# Altium schematic sheet nRF-MCU.SchDoc
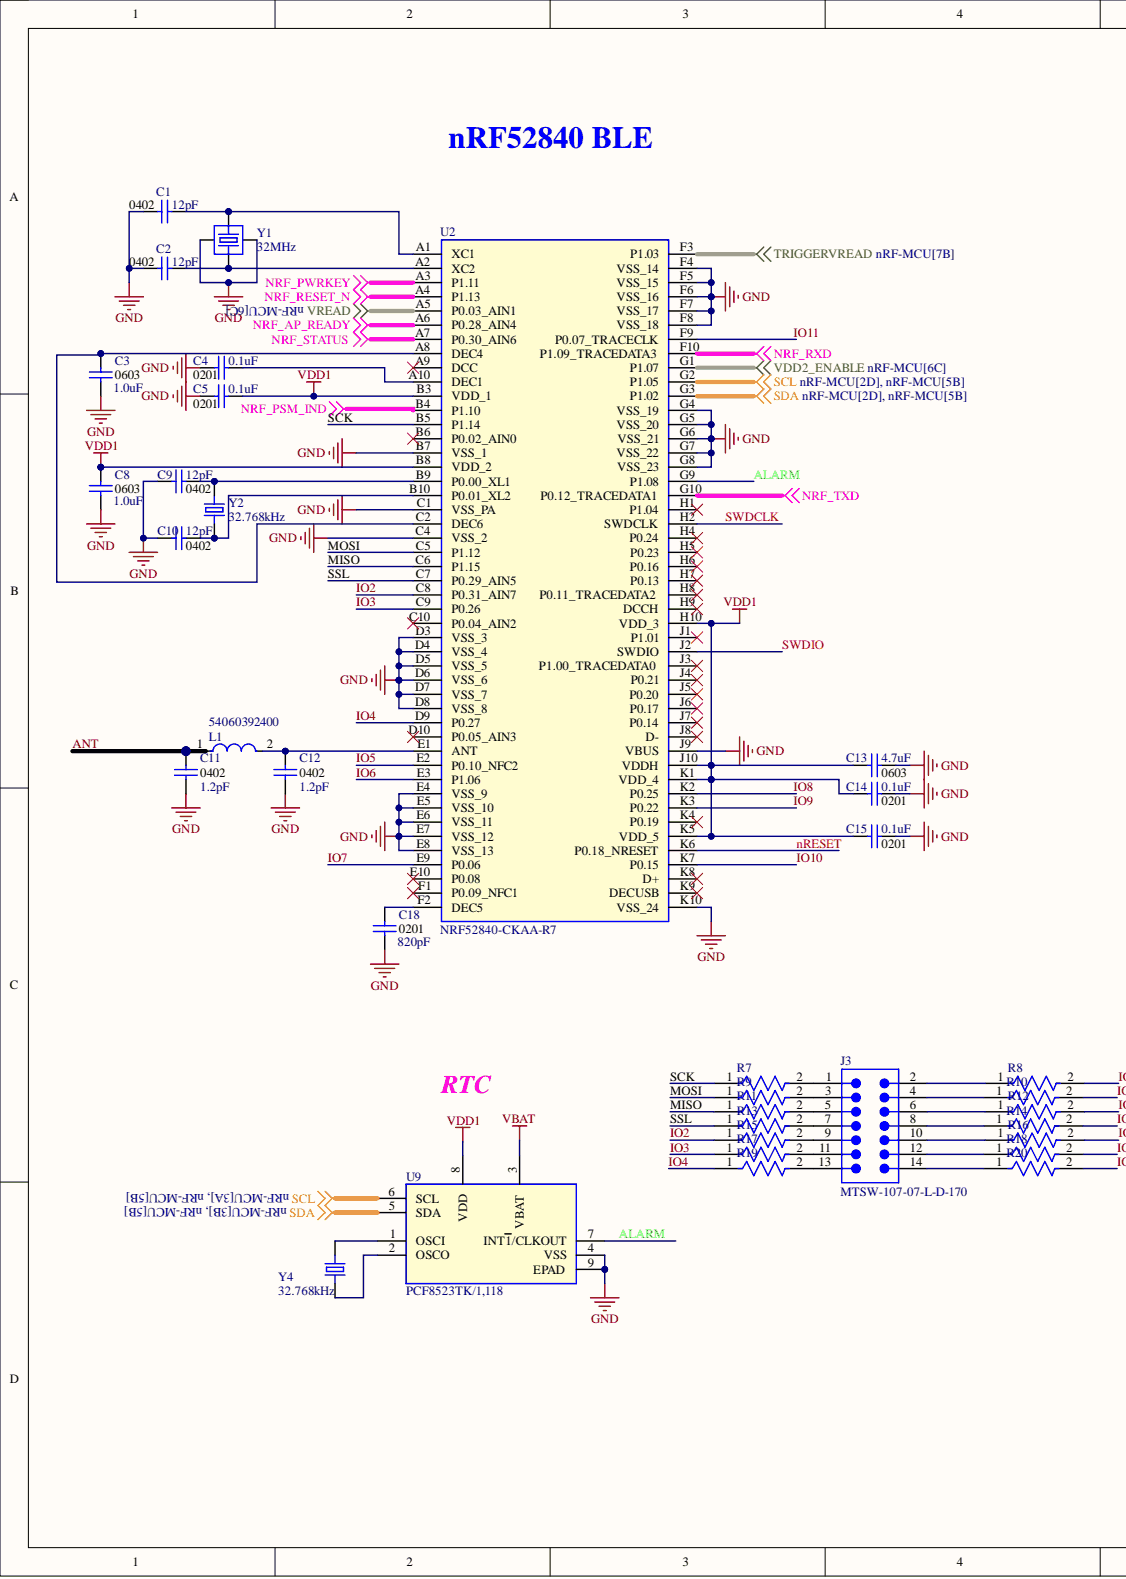
[diagram p0: nRF-MCU.SchDoc, left]
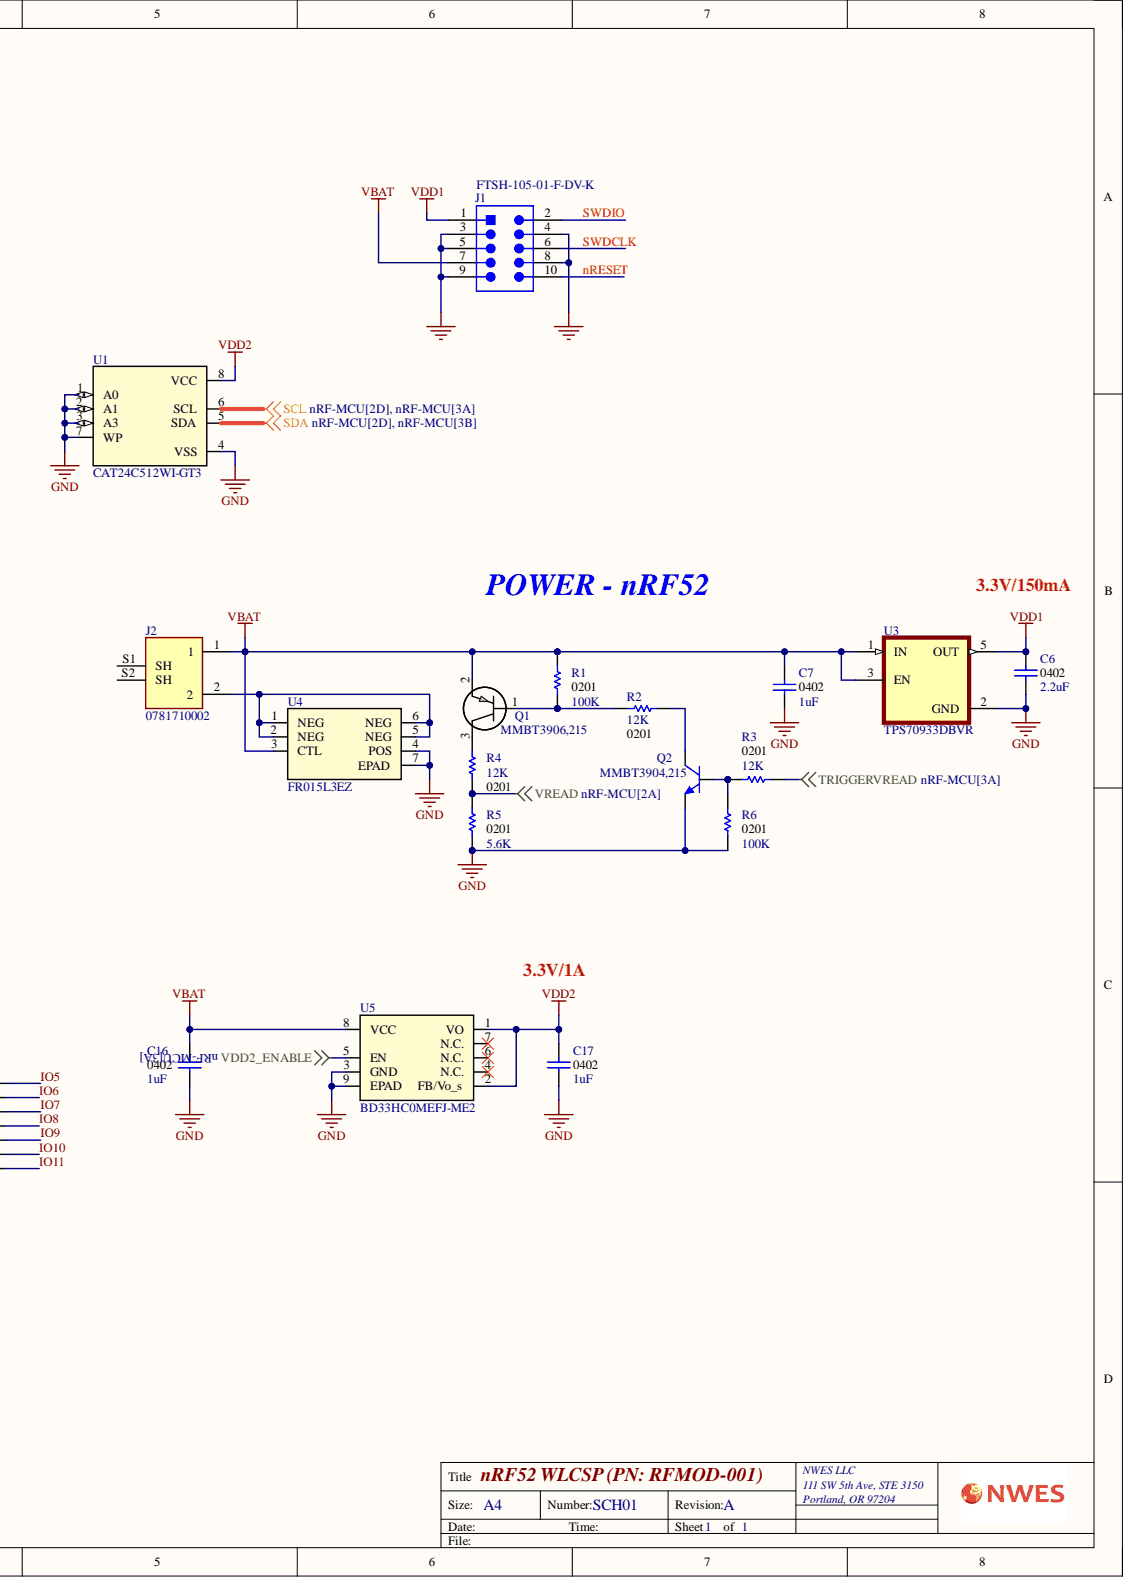
[diagram p1: nRF-MCU.SchDoc, right]

## Parts
C1  12pF  Package: 0402  [CAPC1005X55USR]
C2  12pF  Package: 0402  [CAPC1005X55USR]
C3  1.0uF  Package: 0603  [CAPC1608]
C4  0.1uF  Package: 0201  [CAPC0603X33N]
C5  0.1uF  Package: 0201  [CAPC0603X33N]
C6  2.2uF  Package: 0402  [CAPC1005X55USR]
C7  1uF  Package: 0402  [CAPC1005X55USR]
C8  1.0uF  Package: 0603  [CAPC1608]
C9  12pF  Package: 0402  [CAPC1005X55USR]
C10  12pF  Package: 0402  [CAPC1005X55USR]
C11  1.2pF  Package: 0402  [CAPC1005X55USR]
C12  1.2pF  Package: 0402  [CAPC1005X55USR]
C13  4.7uF  Package: 0603  [CAPC1608]
C14  0.1uF  Package: 0201  [CAPC0603X33N]
C15  0.1uF  Package: 0201  [CAPC0603X33N]
C16  1uF  Package: 0402  [CAPC1005X55USR]
C17  1uF  Package: 0402  [CAPC1005X55USR]
C18  820pF  Package: 0201  [CAPC0603X33N]
J1  FTSH-105-01-F-DV-K  [SMTC-FTSH-105-01-L-DV-K_V]
J2  0781710002  [MOLEX_0781710002]
J3  MTSW-107-07-L-D-170  [SMTC-MTSW-107-07-X-D-170]
L1  54060392400  [FP-LQW18AN_00-MFG]
Q1  MMBT3906,215  [SOT-23]
Q2  MMBT3904,215  [SOT-23]
R1  100K  Package: 0201  [RESC0603X26N]
R2  12K  Package: 0201  [RESC0603X26N]
R3  12K  Package: 0201  [RESC0603X26N]
R4  12K  Package: 0201  [RESC0603X26N]
R5  5.6K  Package: 0201  [RESC0603X26N]
R6  100K  Package: 0201  [RESC0603X26N]
R7  CRCW040222R0JNED  [FP-CRCW0402-e3-MFG]  (value not drawn)
R8  CRCW040222R0JNED  [FP-CRCW0402-e3-MFG]  (value not drawn)
R9  CRCW040222R0JNED  [FP-CRCW0402-e3-MFG]  (value not drawn)
R10  CRCW040222R0JNED  [FP-CRCW0402-e3-MFG]  (value not drawn)
R11  CRCW040222R0JNED  [FP-CRCW0402-e3-MFG]  (value not drawn)
R12  CRCW040222R0JNED  [FP-CRCW0402-e3-MFG]  (value not drawn)
R13  CRCW040222R0JNED  [FP-CRCW0402-e3-MFG]  (value not drawn)
R14  CRCW040222R0JNED  [FP-CRCW0402-e3-MFG]  (value not drawn)
R15  CRCW040222R0JNED  [FP-CRCW0402-e3-MFG]  (value not drawn)
R16  CRCW040222R0JNED  [FP-CRCW0402-e3-MFG]  (value not drawn)
R17  CRCW040222R0JNED  [FP-CRCW0402-e3-MFG]  (value not drawn)
R18  CRCW040222R0JNED  [FP-CRCW0402-e3-MFG]  (value not drawn)
R19  CRCW040222R0JNED  [FP-CRCW0402-e3-MFG]  (value not drawn)
R20  CRCW040222R0JNED  [FP-CRCW0402-e3-MFG]  (value not drawn)
U1  CAT24C512WI-GT3  [SOIC-8]
U2  NRF52840-CKAA-R7  [BGA94C35P10X10_354X361X51]
U3  TPS70933DBVR  [SOT23-5]
U4  FR015L3EZ  [MLP6_2X2_ONS]
U5  BD33HC0MEFJ-ME2  [HTSOP-J8_ROM]
U9  PCF8523TK/1,118  [HVSON80P400X400X100-9N]
Y1  32MHz  [XTAL_2016_ECS_320]
Y2  32.768kHz  [XTAL_3215]
Y4  32.768kHz  [XTAL_3215]

## Nets
GND: C1.2 C2.2 C3.2 C4.2 C5.2 C6.1 C7.1 C8.2 C9.2 C10.2 C11.1 C12.1 C13.1 C14.1 C15.1 C16.1 C17.1 C18.2 J1.3 J1.4 J1.5 J1.8 J1.9 Q2.2 R5.1 R6.1 U1.1(A0) U1.2(A1) U1.3(A3) U1.4(VSS) U1.7(WP) U2.B7(VSS_1) U2.C1(VSS_PA) U2.C4(VSS_2) U2.D3(VSS_3) U2.D4(VSS_4) U2.D5(VSS_5) U2.D6(VSS_6) U2.D7(VSS_7) U2.D8(VSS_8) U2.E4(VSS_9) U2.E5(VSS_10) U2.E6(VSS_11) U2.E7(VSS_12) U2.E8(VSS_13) U2.F4(VSS_14) U2.F5(VSS_15) U2.F6(VSS_16) U2.F7(VSS_17) U2.F8(VSS_18) U2.G4(VSS_19) U2.G5(VSS_20) U2.G6(VSS_21) U2.G7(VSS_22) U2.G8(VSS_23) U2.J9(VBUS) U2.K10(VSS_24) U3.2(GND) U4.4(POS) U4.7(EPAD) U5.3(GND) U5.9(EPAD) U9.4(VSS) U9.9(EPAD) Y1.2 Y1.4
NRF_AP_READY: U2.A6(P0.28_AIN4)
NRF_PSM_IND: U2.B4(P1.10)
NRF_PWRKEY: U2.A3(P1.11)
NRF_RESET_N: U2.A4(P1.13)
NRF_RXD: U2.F10(P1.09_TRACEDATA3)
NRF_STATUS: U2.A7(P0.30_AIN6)
NRF_TXD: U2.G10(P0.12_TRACEDATA1)
SCL: U1.6(SCL) U2.G2(P1.05) U9.6(SCL)
SDA: U1.5(SDA) U2.G3(P1.02) U9.5(SDA)
TRIGGERVREAD: R3.1 U2.F3(P1.03)
VBAT: C7.2 C16.2 J1.7 J2.1 Q1.2 R1.2 U3.1(IN) U3.3(EN) U4.3(CTL) U5.8(VCC) U9.3(VBAT)
VDD1: C5.1 C6.2 C8.1 C13.2 C14.2 C15.2 J1.1 U2.B3(VDD_1) U2.B8(VDD_2) U2.H10(VDD_3) U2.J10(VDDH) U2.K1(VDD_4) U2.K5(VDD_5) U3.5(OUT) U9.8(VDD)
VDD2: C17.2 U1.8(VCC) U5.1(VO) U5.2(FB/Vo_s)
VDD2_ENABLE: U2.G1(P1.07) U5.5(EN)
VREAD: R4.2 R5.2 U2.A5(P0.03_AIN1)
ALARM: U2.G9(P1.08) U9.7(INT1/CLKOUT)
ANT: C11.2 L1.1
IO2: R15.1 U2.C8(P0.31_AIN7)
IO3: R17.1 U2.C9(P0.26)
IO4: R19.1 U2.D9(P0.27)
IO5: R8.2 U2.E2(P0.10_NFC2)
IO6: R10.2 U2.E3(P1.06)
IO7: R12.2 U2.E9(P0.06)
IO8: R14.2 U2.K2(P0.25)
IO9: R16.2 U2.K3(P0.22)
IO10: R18.2 U2.K7(P0.15)
IO11: R20.2 U2.F9(P0.07_TRACECLK)
MISO: R11.1 U2.C6(P1.15)
MOSI: R9.1 U2.C5(P1.12)
nRESET: J1.10 U2.K6(P0.18_NRESET)
SCK: R7.1 U2.B5(P1.14)
SSL: R13.1 U2.C7(P0.29_AIN5)
SWDCLK: J1.6 U2.H2(SWDCLK)
SWDIO: J1.2 U2.J2(SWDIO)
NetC1_1: C1.1 U2.A1(XC1) Y1.1
NetC2_1: C2.1 U2.A2(XC2) Y1.3
NetC3_1: C3.1 U2.A8(DEC4) U2.C2(DEC6)
NetC4_1: C4.1 U2.A10(DEC1)
NetC9_1: C9.1 U2.B9(P0.00_XL1) Y2.2
NetC10_1: C10.1 U2.B10(P0.01_XL2) Y2.1
NetC12_2: C12.2 L1.2 U2.E1(ANT)
NetC18_1: C18.1 U2.F2(DEC5)
NetJ2_2: J2.2 U4.1(NEG) U4.2(NEG) U4.5(NEG) U4.6(NEG)
NetJ3_1: J3.1 R7.2
NetJ3_2: J3.2 R8.1
NetJ3_3: J3.3 R9.2
NetJ3_4: J3.4 R10.1
NetJ3_5: J3.5 R11.2
NetJ3_6: J3.6 R12.1
NetJ3_7: J3.7 R13.2
NetJ3_8: J3.8 R14.1
NetJ3_9: J3.9 R15.2
NetJ3_10: J3.10 R16.1
NetJ3_11: J3.11 R17.2
NetJ3_12: J3.12 R18.1
NetJ3_13: J3.13 R19.2
NetJ3_14: J3.14 R20.1
NetQ1_1: Q1.1 R1.1 R2.2
NetQ1_3: Q1.3 R4.1
NetQ2_1: Q2.1 R3.2 R6.2
NetQ2_3: Q2.3 R2.1
NetU9_1: U9.1(OSCI) Y4.2
NetU9_2: U9.2(OSCO) Y4.1

Not connected: J2 S1(SH) S2(SH); U2 A9(DCC) B6(P0.02_AIN0) C10(P0.04_AIN2) D10(P0.05_AIN3) E10(P0.08) F1(P0.09_NFC1) H1(P1.04) H4(P0.24) H5(P0.23) H6(P0.16) H7(P0.13) H8(P0.11_TRACEDATA2) H9(DCCH) J1(P1.01) J3(P1.00_TRACEDATA0) J4(P0.21) J5(P0.20) J6(P0.17) J7(P0.14) J8(D-) K4(P0.19) K8(D+) K9(DECUSB); U5 4(N.C.) 6(N.C.) 7(N.C.)

## Library data of the parts
C1 C2 C9 C10 = CAP CER 12PF 50V C0G/NP0 0402: Manufacturer: YAGEO; Manufacturer Part Number: CC0402GRNPO9BN120; Component Kind: Standard; Description: CAP CER 12PF 50V C0G/NP0 0402; Height: 0.55mm; Supplier 1: Digi-Key; Supplier Part Number 1: 311-3609-1-ND; value: 12pF; Library Name: nRF52-Intro.SCHLIB
C3 C8 = CAP CER 1UF 16V X7R 0603: Manufacturer: KEMET; Manufacturer Part Number: C0603C105K4RAC7867; Component Kind: Standard; Description: CAP CER 1UF 16V X7R 0603; Height: 0.9mm; Supplier 1: Digi-Key; Supplier Part Number 1: 399-C0603C105K4RAC7867CT-ND; value: 1.0uF; Library Name: nRF52-Intro.SCHLIB
C4 C5 C14 C15 = CAP CER 0.1UF 6.3V X7R 0201: Manufacturer: YAGEO; Manufacturer Part Number: CC0201KRX7R5BB104; Component Kind: Standard; Description: CAP CER 0.1UF 6.3V X7R 0201; Height: 0.33mm; Supplier 1: Digi-Key; Supplier Part Number 1: 13-CC0201KRX7R5BB104CT-ND; value: 0.1uF; Library Name: nRF52-Intro.SCHLIB
C6 = CAP CER 2.2UF 25V X5R 0402: Manufacturer: Murata Electronics; Manufacturer Part Number: GRM155R61E225ME15D; Component Kind: Standard; Description: CAP CER 2.2UF 25V X5R 0402; Height: 0.55mm; Supplier 1: Digi-Key; Supplier Part Number 1: 490-10457-1-ND; value: 2.2uF; Library Name: nRF52-Intro.SCHLIB
C7 C16 C17 = CAP CER 1UF 25V X5R 0402: Manufacturer: Murata Electronics; Manufacturer Part Number: GRM155C81E105ME11J; Component Kind: Standard; Description: CAP CER 1UF 25V X5R 0402; Height: 0.6mm; Supplier 1: Digi-Key; Supplier Part Number 1: 490-GRM155C81E105ME11JCT-ND; value: 1uF; Library Name: nRF52-Intro.SCHLIB
C11 C12 = CAP CER 1.2PF 50V C0G/NP0 0402: Manufacturer: Murata Electronics; Manufacturer Part Number: GCM1555C1H1R2BA16D; Component Kind: Standard; Description: CAP CER 1.2PF 50V C0G/NP0 0402; Height: 0.55mm; Supplier 1: Digi-Key; Supplier Part Number 1: 490-16453-1-ND; value: 1.2pF; Library Name: nRF52-Intro.SCHLIB
C13 = CAP CER 4.7UF 6.3V X7R 0603: Manufacturer: Taiyo Yuden; Manufacturer Part Number: JMK107BB7475KA-T; Component Kind: Standard; Description: CAP CER 4.7UF 6.3V X7R 0603; Height: 1.0mm; Supplier 1: Digi-Key; Supplier Part Number 1: 587-5870-1-ND; value: 4.7uF; Library Name: nRF52-Intro.SCHLIB
C18 = CAP CER 820PF 25V X7R 0201: Manufacturer: YAGEO; Manufacturer Part Number: CC0201KRX7R8BB821; Component Kind: Standard; Description: CAP CER 820PF 25V X7R 0201; Height: 0.33mm; Supplier 1: Digi-Key; Supplier Part Number 1: 311-3698-1-ND; value: 820pF; Library Name: nRF52-Intro.SCHLIB
J1 = CMP-2000-05352-1: Male Header, Pitch 1.27 mm, 2 x 5 Position, Height 6.12 mm, RoHS, Tube; Manufacturer: Samtec; Connector Type: Header,Shrouded; Number of Positions: 10; Number of Positions Loaded: All; Pitch: 0.050"(1.27mm); Category: Connectors,Interconnects; Color: Black; Component Kind: Standard; Contact Finish: Gold; Contact Finish Thickness: 3µin(0.08µm); Contact Mating Length: 0.120"(3.05mm); Contact Type: MalePin; Datasheet URL: http://suddendocs.samtec.com/prints/ftsh-1xx-xx-xxx-dv-xxx-mkt.pdf; Datasheet Version: Rev. ET, 10/1995; Family: RectangularConnectors-Headers,MalePins; Fastening Type: -; Features: -; Library Name: Altium Content Vault; Manufacturer URL: http://www.samtec.com/; Mounting Type: SurfaceMount; Number of Rows: 2; Package Description: Male Header, Pitch 1.27 mm, 2 x 5 Position, Height 5.97 mm, Tail Length 4.32 mm; Package Reference: FTSH-105-01-L-DV-K; Package URL: http://suddendocs.samtec.com/prints/ftsh-1xx-xx-xxx-dv-xxx-footprint.pdf; Packaging: Tube; Row Spacing: 0.050"(1.27mm); Series: FTSH; Termination: Solder
J2 = CONN_0781710002: Pico-EZmate Wire-to-Board Header Vertical Housing Height 1.55mm with Side Friction Locks 2 Circuits; Manufacturer: Molex; Connector Type: Plug; Contact Resistance: 20mR; Max Voltage Rating (AC): 50V; Number of Contacts: 2; Pitch: 1.2mm; Category: Headers and Wire Housings; Color: Black; Component Kind: Standard; ComponentLink1Description: https://datasheet.ciiva.com/738/781710002-sd-738384.pdf?src-supplier=Digi-Key; ComponentLink1URL: https://datasheet.ciiva.com/738/781710002-sd-738384.pdf?src-supplier=Digi-Key; ComponentLink2Description: https://datasheet.ciiva.com/738/781710002-sd-738387.pdf?src-supplier=Digi-Key; ComponentLink2URL: https://datasheet.ciiva.com/738/781710002-sd-738387.pdf?src-supplier=Digi-Key; ComponentLink3Description: https://datasheet.ciiva.com/738/781710002-sd-738390.pdf?src-supplier=Digi-Key; ComponentLink3URL: https://datasheet.ciiva.com/738/781710002-sd-738390.pdf?src-supplier=Digi-Key; Contact Material: Copper Alloy; Contact Plating: Gold; Contact Type: Tab; Depth: 4.5mm; Fastening Type: Friction; Housing Color: Black; Housing Material: Polymer; Length: 4.2mm; Manufacturer Part Number: 0781710002; Max Operating Temperature: 85°C; Max Voltage Rating (DC): 50V; Min Operating Temperature: -25°C; Mount: Surface Mount; Number of Positions: 2; Number of Rows: 1; Orientation: Straight; Packaging: Tape and Reel; Pricing 1: 1=0.40000, 10=0.32600, 100=0.23890, 1000=0.19000 (USD); Radiation Hardening: No; RoHS: Yes; RoHS Compliant: Yes; Series: Pico-EZmate™ 78171; Stock 1: 156886; Supplier 1: Digi-Key; Supplier Part Number 1: WM4459CT-ND; Termination: Solder; Library Name: nRF52-Intro.SCHLIB
J3 = CMP-1024-00128-1: Modified .025" SQ Post Header, Through-hole, Vertical, -55 to 125 degC, 2.54 mm Pitch, 14-Pin, Male, RoHS; Manufacturer: Samtec; Pitch: 2.54 mm; Component Kind: Standard; ComponentLink1Description: Manufacturer URL; ComponentLink1URL: http://www.samtec.com; ComponentLink2Description: Datasheet; ComponentLink2URL: http://www.samtec.com/documents/webfiles/pdf/MTSW.PDF; ComponentLink3Description: Package Specification; ComponentLink3URL: http://www.samtec.com/documents/webfiles/cpdf/MTSW-XXX-XX-X-X-XXXX-XX-XXX-MKT1.pdf; DatasheetVersion: F-214; Library Name: Altium Content Vault; Mounting Technology: Through-hole; Orientation: Vertical; PackageDescription: Assembly .025" [.64] SQ Post Terminal Strip; PackageReference: MTSW-107-07-X-D-170; PackageVersion: BI, 2/1987; PartNumber: MTSW-107-07-L-D-170; RoHS: True; Temperature Range: -55 to 125 degC
L1 = CMP-06042-012062-1: Fixed Inductors RF Chip Inductor SMD 3.9nH 0603 10% Tol; Manufacturer: Sumida; Core Material: Non-Magnetic; Current: 200mA; Current Rating: 750mA; DC Resistance (DCR): 82mR; Q Factor: 35; Self Resonant Frequency: 6GHz; Series Resistance: 35mR; Shielding: Unshielded; Test Frequency: 100MHz; Tolerance: 2%; Case Code (Imperial): 0603; Case Code (Metric): 1608; Case/Package: 0603; Component Kind: Standard; DC Current: 750mA; Depth: 1mm; Height: 0.8mm; Height - Seated (Max): 0.039inch; Inductance: 3.9nH; Lead Free: Lead Free; Length: 1.6mm; Library Name: Altium Content Vault; Manufacturer Part  Number: 54060392400; Max DC Current: 750mA; Max Operating Temperature: 125°C; Military Standard: Not; Min Operating Temperature: -55°C; Mount: Surface Mount; Package Quantity: 4000; Packaging: Tape and Reel; Radiation Hardening: No; Resistor Type: Wirewound; Termination: SMD/SMT; Weight: 0.000106oz; Width: 0.031inch
Q1 = PNP_MMBT3906,215: TRANS PNP 40V 0.2A TO236AB; Manufacturer: Nexperia; Category: BJTs; Component Kind: Standard; ComponentLink1Description: http://www.farnell.com/datasheets/454048.pdf?src-supplier=Farnell; ComponentLink1URL: http://www.farnell.com/datasheets/454048.pdf?src-supplier=Farnell; ComponentLink2Description: https://assets.nexperia.com/documents/data-sheet/MMBT3906.pdf?src-supplier=Digi-Key; ComponentLink2URL: https://assets.nexperia.com/documents/data-sheet/MMBT3906.pdf?src-supplier=Digi-Key; ComponentLink3Description: http://www.nxp.com/packings/SOT23_215.html?src-supplier=Farnell; ComponentLink3URL: http://www.nxp.com/packings/SOT23_215.html?src-supplier=Farnell; Manufacturer Part Number: MMBT3906,215; Pricing 1: 1=0.14000, 10=0.12800, 100=0.06970, 500=0.04290, 1000=0.02926 (USD); RoHS: Yes; RoHS Compliant: Yes; Stock 1: 1789; Supplier 1: Digi-Key; Supplier Part Number 1: 1727-4045-1-ND; Library Name: nRF52-Intro.SCHLIB
Q2 = NPN_MMBT3904,215: TRANS NPN 40V 0.2A SOT23; Manufacturer: Nexperia; Category: BJTs; Component Kind: Standard; ComponentLink1Description: http://www.farnell.com/datasheets/2328888.pdf?src-supplier=Farnell; ComponentLink1URL: http://www.farnell.com/datasheets/2328888.pdf?src-supplier=Farnell; ComponentLink2Description: https://assets.nexperia.com/documents/data-sheet/MMBT3904.pdf?src-supplier=Digi-Key; ComponentLink2URL: https://assets.nexperia.com/documents/data-sheet/MMBT3904.pdf?src-supplier=Digi-Key; ComponentLink3Description: http://www.farnell.com/datasheets/2328888.pdf?src-supplier=Newark; ComponentLink3URL: http://www.farnell.com/datasheets/2328888.pdf?src-supplier=Newark; Manufacturer Part Number: MMBT3904,215; Pricing 1: 1=0.13000, 10=0.11800, 100=0.06440, 500=0.03964, 1000=0.02703 (USD); RoHS: Yes; RoHS Compliant: Yes; Stock 1: 1634308; Supplier 1: Digi-Key; Supplier Part Number 1: 1727-4044-1-ND; Library Name: nRF52-Intro.SCHLIB
R1 R6 = RES 100K OHM 1% 1/20W 0201: Manufacturer: Yageo; Manufacturer Part Number: RC0201FR-07100KL; Component Kind: Standard; Description: RES 100K OHM 1% 1/20W 0201; Height: 0.26mm; Supplier 1: Digi-Key; Supplier Part Number 1: 311-100KMCT-ND; value: 100K; Library Name: nRF52-Intro.SCHLIB
R2-R4 = RES 12K OHM 1% 1/20W 0201: Manufacturer: Yageo; Manufacturer Part Number: RC0201FR-0712KL; Component Kind: Standard; Description: RES 12K OHM 1% 1/20W 0201; Height: 0.26mm; Supplier 1: Digi-Key; Supplier Part Number 1: 311-12.0KMCT-ND; value: 12K; Library Name: nRF52-Intro.SCHLIB
R5 = RES 5.6K OHM 1% 1/20W 0201: Manufacturer: Yageo; Manufacturer Part Number: RC0201FR-075K6L; Component Kind: Standard; Description: RES 5.6K OHM 1% 1/20W 0201; Height: 0.26mm; Supplier 1: Digi-Key; Supplier Part Number 1: YAG2346CT-ND; value: 5.6K; Library Name: nRF52-Intro.SCHLIB
R7-R20 = CMP-1011-00034-3: RES Thick Film, 22Ω, 5%, 0.063W, 200ppm/°C, 0402; Max Power Dissipation: 63mW; Resistance: 22R; Voltage Rating: 50V; Case Code (Imperial): 0402; Case Code (Metric): 1005; Case/Package: 0402; Component Kind: Standard; Composition: Thick Film; Contact Plating: Tin; Depth: 0.5mm; Features: Automotive AEC-Q200; Height: 0.016inch; Length: 1mm; Library Name: Altium Content Vault; Max Operating Temperature: 155°C; Military Standard: Not; Min Operating Temperature: -55°C; Number of Pins: 2; Number of Terminations: 2; Package Quantity: 1; Packaging: Tape and Reel; Power Rating: 63mW; Temperature Coefficient: 200ppm/°C; Termination: SMD/SMT; Tolerance: 5%; Weight: 2.3E-05oz; Width: 0.5mm
U1 = CAT24C512WI-GT3: IC EEPROM 512KBIT 1MHZ 8SOIC; Manufacturer: ON Semiconductor; Access Time: 3500ns; Access Time-Max: 900ns; Density: 512Kb; Frequency: 100kHz; Interface: I2C,Serial,2-Wire; Max Frequency: 400kHz; Max Supply Voltage: 5.5V; Min Supply Voltage: 1.8V; Nominal Supply Current: 2.5mA; Number of Words: 64000; Operating Supply Voltage: 5V; Organization: 32KX8; Write Cycle Time-Max (tWC): 5ms; Write Protection: YES; Case/Package: SOIC; Category: EEPROM; Component Kind: Standard; ComponentLink1Description: https://datasheet.ciiva.com/22618/1674429-22618480.pdf?src-supplier=Element14; ComponentLink1URL: https://datasheet.ciiva.com/22618/1674429-22618480.pdf?src-supplier=Element14; ComponentLink2Description: https://datasheet.ciiva.com/25627/0900766b810de298-25627756.pdf?src-supplier=RSComponents; ComponentLink2URL: https://datasheet.ciiva.com/25627/0900766b810de298-25627756.pdf?src-supplier=RSComponents; Contact Plating: Gold; Halogen Free: Halogen Free; Height: 1.5mm; Lead Free: Lead Free; Length: 5mm; Manufacturer Part Number: CAT24C512WI-GT3; Max Operating Temperature: 85°C; Memory Size: 512Kb; Memory Type: EEPROM; Min Operating Temperature: -40°C; Mount: Surface Mount; Number of Pins: 8; Package Quantity: 1; Packaging: Tape and Reel; Pricing 1: 1=0.68000, 10=0.62300, 50=0.61200, 100=0.54700, 1000=0.51600, 10000=0.50800 (USD); Radiation Hardening: No; REACH SVHC: No SVHC; RoHS: Yes; RoHS Compliant: Yes; Series: -; Stock 1: 254725; Supplier 1: Mouser; Supplier Part Number 1: 863-CAT24C512WI-GT3; Supply Current: 2.5mA; Supply Voltage-Nom: 5.5V; Width: 4mm; Library Name: nRF52-Intro.SCHLIB
U2 = NRF52840-CKAA-R7: Advanced Bluetooth 5, Thread and Zigbee multiprotocol SoC; Manufacturer: Nordic Semiconductor; Category: RF Receivers, Transceivers; Component Kind: Standard; Manufacturer Part Number: NRF52840-CKAA-R7; Pricing 1: 1=6.69000, 10=6.04000, 50=5.76000, 100=5.00000, 1000=5.00000, 10000=5.00000 (USD); RoHS: Yes; Supplier 1: Mouser; Supplier Part Number 1: 949-NRF52840-CKAA-R7; Library Name: nRF52-Intro.SCHLIB
U3 = TPS70933DBVR: Linear Voltage Regulator IC Positive Fixed 1 Output 3.3V 150mA SOT-23-5; Manufacturer: Texas Instruments; Accuracy: 2%; Dropout Voltage: 960mV; Max Input Voltage: 30V; Min Current Limit: 200mA; Min Input Voltage: 2.7V; Number of Outputs: 1; Output Voltage Accuracy: 1%; Power Supply Rejection Ratio (PSRR): 80dB; Quiescent Current: 1uA; Case/Package: SOT-23-5; Category: Voltage Regulators - Linear; Component Kind: Standard; ComponentLink1Description: https://datasheet.ciiva.com/6580/tps70933-6580912.pdf?src-supplier=Digi-Key; ComponentLink1URL: https://datasheet.ciiva.com/6580/tps70933-6580912.pdf?src-supplier=Digi-Key; ComponentLink2Description: https://datasheet.ciiva.com/6580/tps709-6580908.pdf?src-supplier=Digi-Key; ComponentLink2URL: https://datasheet.ciiva.com/6580/tps709-6580908.pdf?src-supplier=Digi-Key; ComponentLink3Description: https://datasheet.ciiva.com/6580/tps709-6580911.pdf?src-supplier=Digi-Key; ComponentLink3URL: https://datasheet.ciiva.com/6580/tps709-6580911.pdf?src-supplier=Digi-Key; Lead Free: Lead Free; Manufacturer Part Number: TPS70933DBVR; Max Operating Temperature: 125°C; Max Output Current: 150mA; Min Operating Temperature: -40°C; Mount: Surface Mount; Nominal Output Voltage: 3.3V; Number of Pins: 5; Number of Regulators: 1; Output Current: 150mA; Output Type: Fixed; Output Voltage: 3.3V; Package Quantity: 1; Packaging: Tape and Reel; Polarity: Positive; Pricing 1: 1=1.37000, 10=1.22300, 25=1.16040, 100=0.95330, 250=0.89108, 500=0.78748, 1000=0.62560 (USD); Radiation Hardening: No; REACH SVHC: No SVHC; RoHS: Yes; RoHS Compliant: Yes; Series: -; Stock 1: 84982; Supplier 1: Digi-Key; Supplier Part Number 1: 296-35483-1-ND; Library Name: nRF52-Intro.SCHLIB
U4 = FR015L3EZ: LOW SIDE REV BIAS POLAR PROTECT; Manufacturer: ON Semiconductor / Fairchild; Breakdown Voltage: 14.5V; Clamping Voltage: 15V; Current: 8A; Max Power Dissipation: 2.4W; Number of Channels: 1; Operating Supply Voltage: 8V; Polarity: Unidirectional; Voltage: 1.3V; Category: EMI / RFI Components; Component Kind: Standard; ComponentLink1Description: https://datasheet.ciiva.com/13084/fr015l3ez-113107-13084211.pdf?src-supplier=Mouser; ComponentLink1URL: https://datasheet.ciiva.com/13084/fr015l3ez-113107-13084211.pdf?src-supplier=Mouser; ComponentLink2Description: https://datasheet.ciiva.com/6702/fr015l3ez-6702465.pdf?src-supplier=Digi-Key; ComponentLink2URL: https://datasheet.ciiva.com/6702/fr015l3ez-6702465.pdf?src-supplier=Digi-Key; Height: 0.725mm; Lead Free: Lead Free; Length: 2mm; Manufacturer Part Number: FR015L3EZ; Max Operating Temperature: 125°C; Min Operating Temperature: -55°C; Mount: Surface Mount; Number of Circuits: 1; Number of Outputs: 1; Number of Pins: 6; Package Quantity: 3000; Packaging: Tape and Reel; Peak Pulse Power: 2.4W; Pricing 1: 1=0.71000, 10=0.62700, 50=0.62700, 100=0.48000, 1000=0.30400, 10000=0.26700 (USD); RoHS: Yes; RoHS Compliant: Yes; Series: FR015; Stock 1: 21380; Supplier 1: Mouser; Supplier Part Number 1: 512-FR015L3EZ; Termination: SMD/SMT; Weight: 0.03g; Width: 2mm; Working Voltage: 8V; Library Name: nRF52-Intro.SCHLIB
U5 = BD33HC0MEFJ-ME2: LDO Regulator Pos 3.3V 1A Automotive 8-Pin HTSOP-J EP T/R; Manufacturer: Rohm; Dropout Voltage: 600mV; Input Bias Current: 600uA; Max Input Voltage: 8V; Max Power Dissipation: 2.11W; Min Input Voltage: 4.5V; Number of Outputs: 1; Output Type: Fixed; Category: Voltage Regulators - Linear; Component Kind: Standard; ComponentLink1Description: https://datasheet.ciiva.com/29568/bdxxhc0mefj-m-e-29568538.pdf?src-supplier=Digi-Key; ComponentLink1URL: https://datasheet.ciiva.com/29568/bdxxhc0mefj-m-e-29568538.pdf?src-supplier=Digi-Key; ComponentLink2Description: https://datasheet.ciiva.com/29568/bdxxhc0mefj-m-e-29568539.pdf?src-supplier=Digi-Key; ComponentLink2URL: https://datasheet.ciiva.com/29568/bdxxhc0mefj-m-e-29568539.pdf?src-supplier=Digi-Key; ComponentLink3Description: https://datasheet.ciiva.com/29569/bdxxhc0mefj-m-e-29569161.pdf?src-supplier=Digi-Key; ComponentLink3URL: https://datasheet.ciiva.com/29569/bdxxhc0mefj-m-e-29569161.pdf?src-supplier=Digi-Key; ComponentLink4Description: https://datasheet.ciiva.com/48677/bdxxhc0mefj-m-e-48677678.pdf?src-supplier=Avnet; ComponentLink4URL: https://datasheet.ciiva.com/48677/bdxxhc0mefj-m-e-48677678.pdf?src-supplier=Avnet; Manufacturer Part Number: BD33HC0MEFJ-ME2; Max Operating Temperature: 105°C; Max Output Current: 1A; Min Operating Temperature: -40°C; Mount: Surface Mount; Nominal Output Voltage: 3.3V; Number of Pins: 8; Number of Regulators: 1; Output Current: 1A; Output Voltage: 3.3V; Package Quantity: 1; Packaging: Tape and Reel; Pricing 1: 1=1.50000, 10=1.34500, 25=1.27640, 100=1.04830, 250=0.97996, 500=0.86602, 1000=0.68370 (USD); REACH SVHC: Unknown; RoHS: Yes; RoHS Compliant: Yes; Stock 1: 1946; Supplier 1: Digi-Key; Supplier Part Number 1: BD33HC0MEFJ-ME2CT-ND; Library Name: nRF52-Intro.SCHLIB
U9 = PCF8523TK/1,118: PCF8523 Series 5.5 V 1 Mbps I2C Interface Real Time Clock / Calendar - HVSON-8; Manufacturer: NXP Semiconductors; Max Supply Voltage: 5.5V; Min Supply Voltage: 1.2V; Time Format: HH:MM:SS; Case/Package: HVSON; Category: Real Time Clocks; Component Kind: Standard; ComponentLink1Description: https://datasheet.ciiva.com/1238/pcf8523-1238777.pdf?src-supplier=Digi-Key; ComponentLink1URL: https://datasheet.ciiva.com/1238/pcf8523-1238777.pdf?src-supplier=Digi-Key; ComponentLink2Description: https://datasheet.ciiva.com/1238/pcf8523-1238778.pdf?src-supplier=Digi-Key; ComponentLink2URL: https://datasheet.ciiva.com/1238/pcf8523-1238778.pdf?src-supplier=Digi-Key; ComponentLink3Description: https://datasheet.ciiva.com/1238/pcf8523-1238779.pdf?src-supplier=Digi-Key; ComponentLink3URL: https://datasheet.ciiva.com/1238/pcf8523-1238779.pdf?src-supplier=Digi-Key; ComponentLink4Description: https://datasheet.ciiva.com/8144/pcf8523-8144533.pdf?src-supplier=Avnet; ComponentLink4URL: https://datasheet.ciiva.com/8144/pcf8523-8144533.pdf?src-supplier=Avnet; ComponentLink5Description: https://datasheet.ciiva.com/16355/pcf8523-16355845.pdf?src-supplier=Future+Electronics; ComponentLink5URL: https://datasheet.ciiva.com/16355/pcf8523-16355845.pdf?src-supplier=Future+Electronics; Interface: I2C; Lead Free: Lead Free; Logic Function: Clock; Manufacturer Part Number: PCF8523TK/1,118; Max Operating Temperature: 85°C; Min Operating Temperature: -40°C; Mount: Surface Mount; Number of Pins: 8; Package Quantity: 6000; Packaging: Tape and Reel; Pricing 1: 1=1.91000, 10=1.71600, 25=1.61920, 100=1.37950, 250=1.29528, 500=1.13336, 1000=1.02389 (USD); Radiation Hardening: No; REACH SVHC: No SVHC; RoHS: Yes; RoHS Compliant: Yes; Stock 1: 85182; Supplier 1: Digi-Key; Supplier Part Number 1: 568-6648-1-ND; Supply Voltage-Nom: 5.5V; Temperature Grade: INDUSTRIAL; Library Name: HS Lockbox.SCHLIB
Y1 = XTAL_ECS-320-8-37BQ-CKY-TR: Crystal 32MHz 8pF ±10ppm ±10ppm 4-Pin Miniature CSMD T/R; Manufacturer: ECS International; Component Kind: Standard; Manufacturer Part Number: ECS-320-8-37BQ-CKY-TR; Pricing 1: 1=0.57000, 10=0.47700, 50=0.42960, 100=0.38180, 500=0.36270 (USD); RoHS: No; Stock 1: 8978; Supplier 1: Digi-Key; Supplier Part Number 1: 50-ECS-320-8-37BQ-CKY-CT-ND; Library Name: nRF52-Intro.SCHLIB
Y2 = XTAL_ABS07-32.768KHZ-9-1-T: ABS07 Series 3.2 x 1.5 x 0.9 mm 32.768 KHz ±10ppm 9 pF -40 to 85°C Crystal SMT; Manufacturer: Abracon; ESR (Equivalent Series Resistance): 70kR; Load Capacitance: 9pF; Case/Package: SMD/SMT; Category: Crystals; Component Kind: Standard; ComponentLink1Description: https://datasheet.ciiva.com/12389/abs07-11028-12389874.pdf?src-supplier=Mouser; ComponentLink1URL: https://datasheet.ciiva.com/12389/abs07-11028-12389874.pdf?src-supplier=Mouser; Frequency: 32.768kHz; Frequency Tolerance: 10ppm; Height: 0.035inch; Lead Free: Lead Free; Length: 0.126inch; Manufacturer Part Number: ABS07-32.768KHZ-9-1-T; Max Operating Temperature: 85°C; Min Operating Temperature: -40°C; Mount: Surface Mount; Operating Frequency: 32.768 kHz; Package Quantity: 3000; Packaging: Tape and Reel; Pricing 1: 1=1.50000, 10=1.44000, 50=1.40000, 100=1.20000, 1000=0.98500, 10000=0.90100 (USD); RoHS: Yes; RoHS Compliant: Yes; Series: ABS07; Stock 1: 54228; Supplier 1: Mouser; Supplier Part Number 1: 815-ABS07-32.7K9-1-T; Termination: SMD/SMT; Tolerance: 10ppm; Width: 0.061inch; Library Name: nRF52-Intro.SCHLIB
Y4 = XTAL_ABS07-32.768KHZ-7-T: Crystal 0.032768MHz ±20ppm (Tol) 7pF Flexural 70000Ohm 2-Pin CSMD T/R; Manufacturer: Abracon; ESR (Equivalent Series Resistance): 70kR; Insulation Resistance: 500MR; Case/Package: CSMD; Category: Crystals; Component Kind: Standard; ComponentLink1Description: https://datasheet.ciiva.com/3174/abs07-3174144.pdf?src-supplier=Avnet; ComponentLink1URL: https://datasheet.ciiva.com/3174/abs07-3174144.pdf?src-supplier=Avnet; ComponentLink2Description: https://datasheet.ciiva.com/855/abs07-855945.pdf?src-supplier=Digi-Key; ComponentLink2URL: https://datasheet.ciiva.com/855/abs07-855945.pdf?src-supplier=Digi-Key; ComponentLink3Description: https://datasheet.ciiva.com/855/abs07-855946.pdf?src-supplier=Digi-Key; ComponentLink3URL: https://datasheet.ciiva.com/855/abs07-855946.pdf?src-supplier=Digi-Key; ComponentLink4Description: https://datasheet.ciiva.com/855/abs07-855947.pdf?src-supplier=Digi-Key; ComponentLink4URL: https://datasheet.ciiva.com/855/abs07-855947.pdf?src-supplier=Digi-Key; ComponentLink5Description: https://datasheet.ciiva.com/8344/abs07-8344589.pdf?src-supplier=Avnet; ComponentLink5URL: https://datasheet.ciiva.com/8344/abs07-8344589.pdf?src-supplier=Avnet; ComponentLink6Description: https://datasheet.ciiva.com/16199/abs07-16199467.pdf?src-supplier=Future+Electronics; ComponentLink6URL: https://datasheet.ciiva.com/16199/abs07-16199467.pdf?src-supplier=Future+Electronics; Depth: 1.5mm; Frequency: 32.768kHz; Frequency Stability: 20ppm; Frequency Tolerance: 20ppm; Height: 0.035inch; Lead Free: Lead Free; Length: 3.2mm; Load Capacitance: 7pF; Manufacturer Part Number: ABS07-32.768KHZ-7-T; Max Operating Temperature: 85°C; Min Operating Temperature: -40°C; Mount: Surface Mount; Number of Pins: 2; Operating Frequency: 32.768 kHz; Package Quantity: 3000; Packaging: Tape and Reel; Pricing 1: 1=1.50000, 10=1.43600, 50=1.40240, 100=1.20200, 500=1.16864, 1000=0.98500 (USD); Radiation Hardening: No; REACH SVHC: No SVHC; RoHS: Yes; Series: ABS07; Series Resistance: 70kR; Stock 1: 994435; Supplier 1: Digi-Key; Supplier Part Number 1: 535-9543-1-ND; Termination: SMD/SMT; Tolerance: 20ppm; Width: 1.5mm; Library Name: HS Lockbox.SCHLIB
